# Altium schematic sheet TestBoard.SchDoc
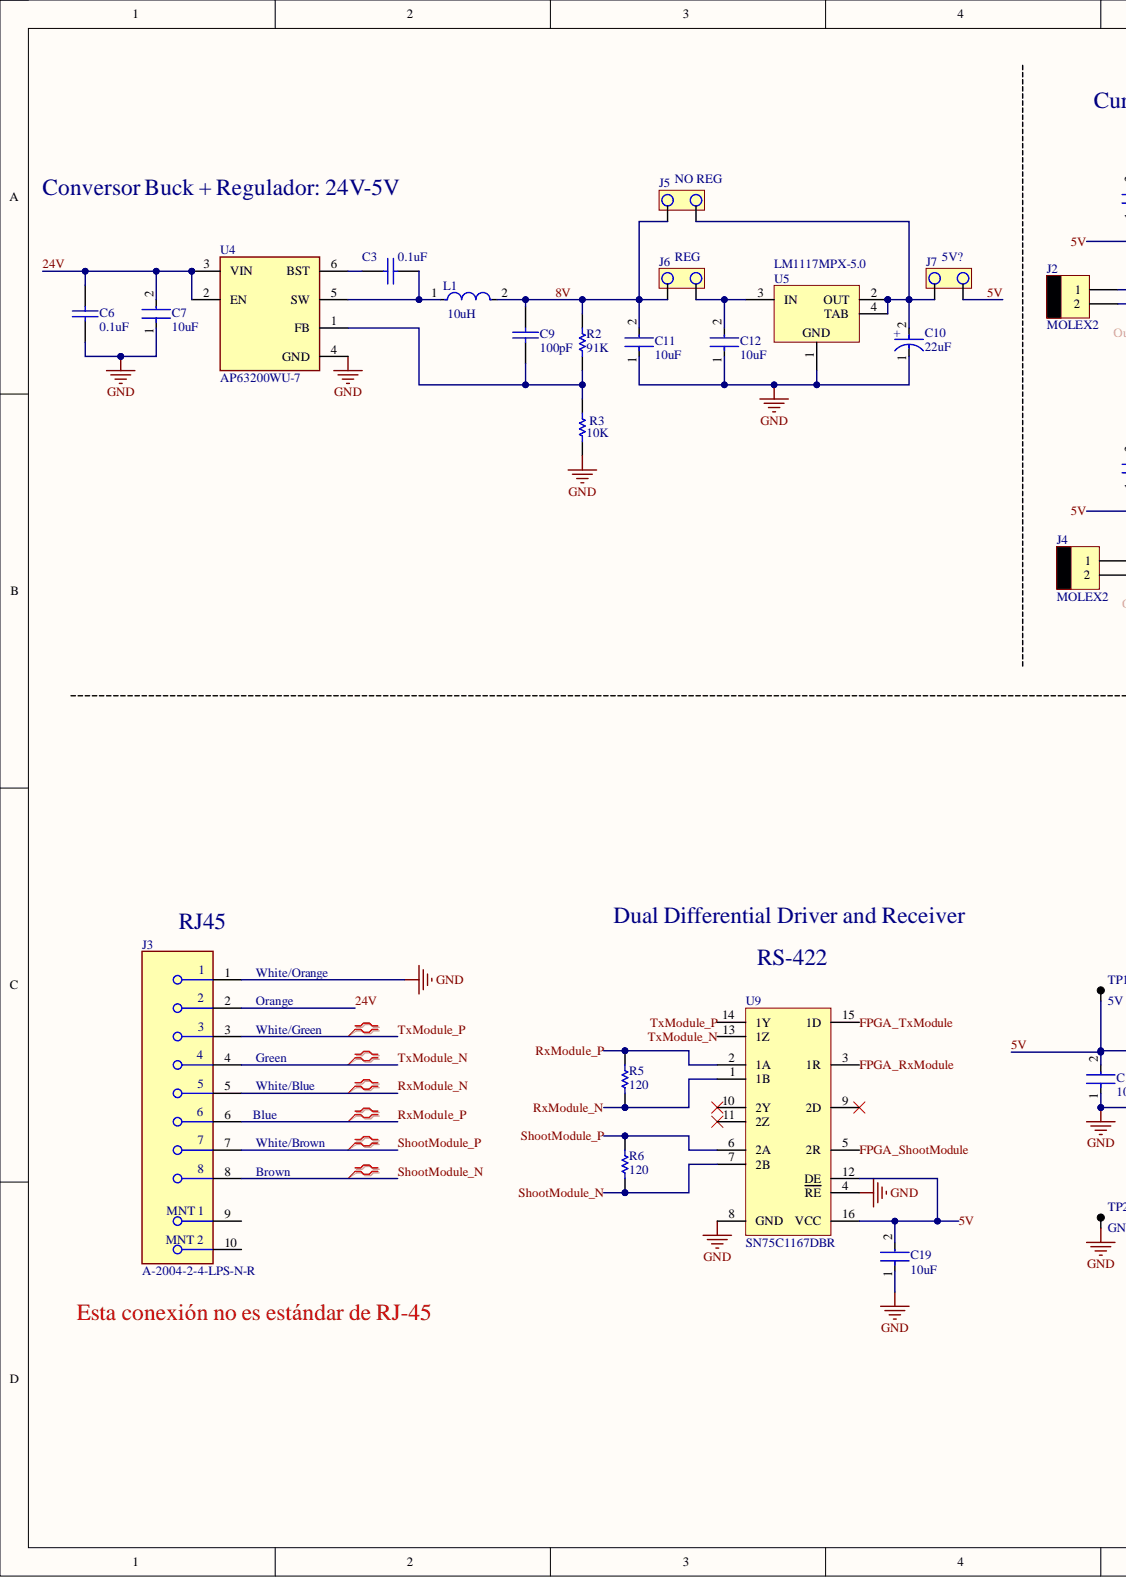
[diagram p0: TestBoard.SchDoc, left]
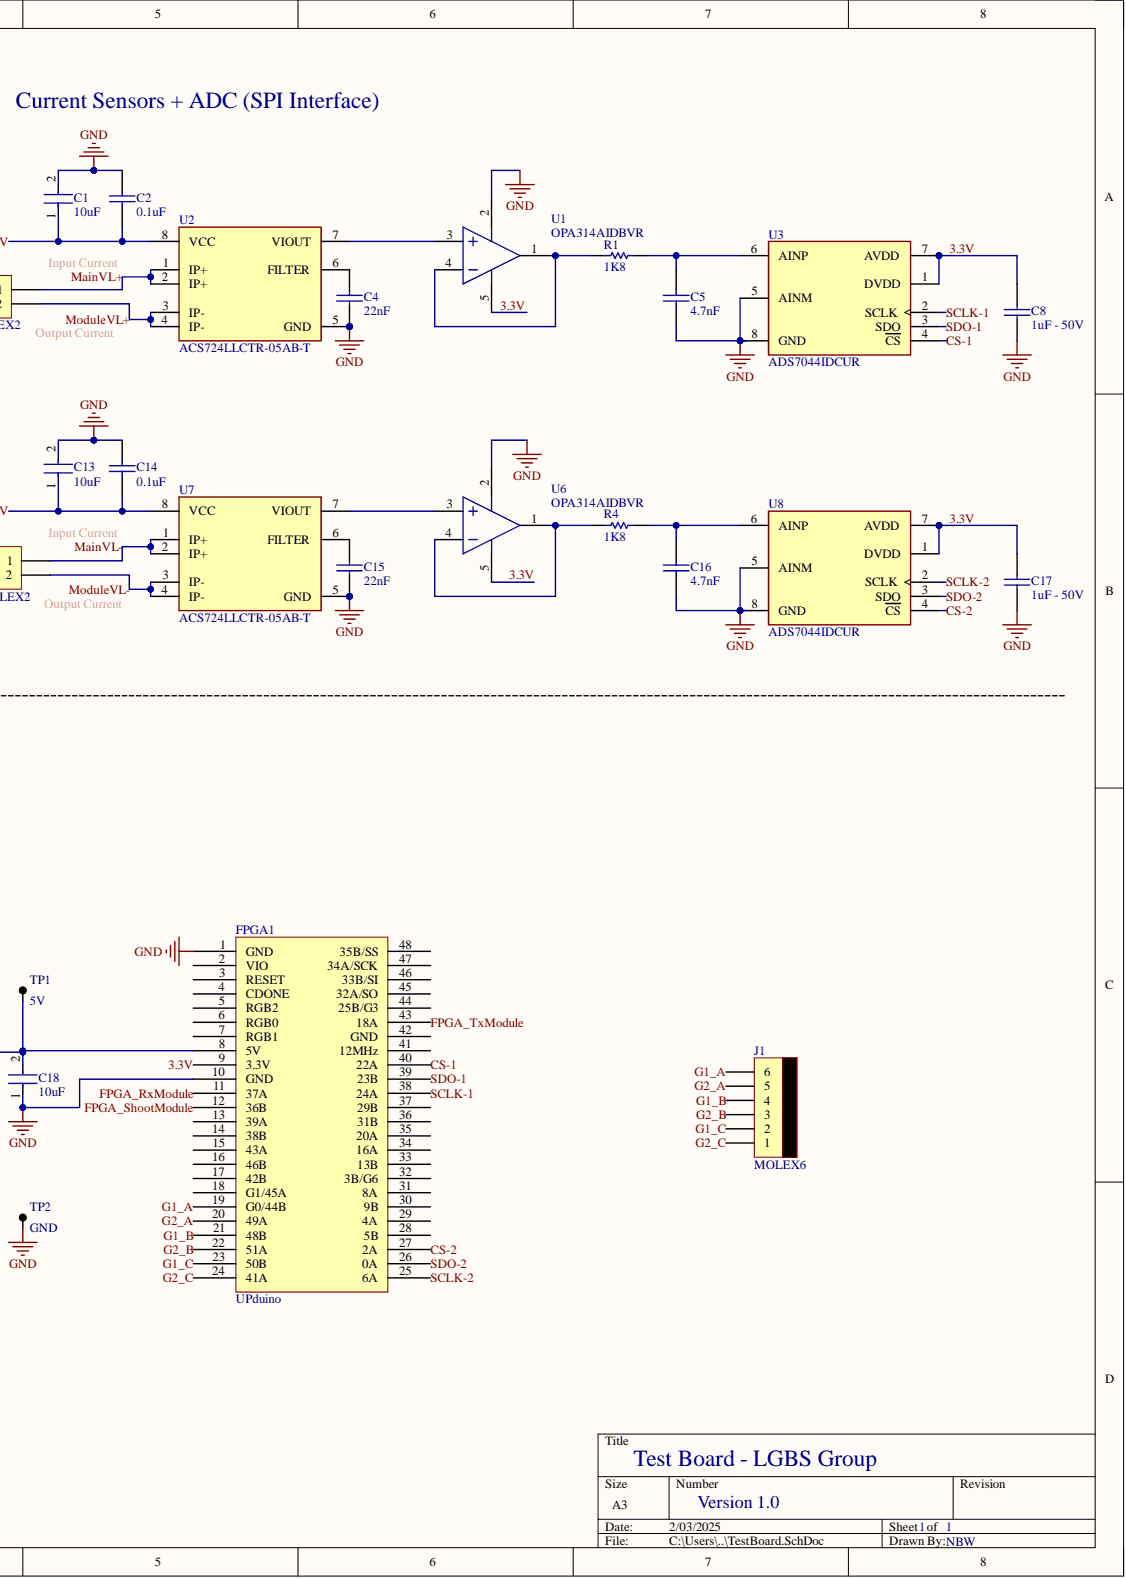
[diagram p1: TestBoard.SchDoc, right]

## Parts
C1  10uF  [FP-CL31B106KBHNNNE-IPC_A]
C2  0.1uF  [0805]
C3  0.1uF  [0805]
C4  22nF  [0805]
C5  4.7nF  [0805]
C6  0.1uF  [0805]
C7  10uF  [FP-CL31B106KBHNNNE-IPC_A]
C8  1uF - 50V  [0805]
C9  100pF  [0805]
C10  22uF  [FP-293DC-MFG]
C11  10uF  [FP-CL31B106KBHNNNE-IPC_A]
C12  10uF  [FP-CL31B106KBHNNNE-IPC_A]
C13  10uF  [FP-CL31B106KBHNNNE-IPC_A]
C14  0.1uF  [0805]
C15  22nF  [0805]
C16  4.7nF  [0805]
C17  1uF - 50V  [0805]
C18  10uF  [FP-CL31B106KBHNNNE-IPC_A]
C19  10uF  [FP-CL31B106KBHNNNE-IPC_A]
FPGA1  UPduino  [FPGA]
J1  MOLEX6  [MOLEX6]
J2  MOLEX2  [MOLEX2]
J3  A-2004-2-4-LPS-N-R  [FP-A-2004-2-4-LPS-N-R-MFG]
J4  MOLEX2  [MOLEX2]
J5  NO REG  [JUMPER2]
J6  REG  [JUMPER2]
J7  5V?  [JUMPER2]
L1  10uH  [FP-NR6045-MFG]
R1  1K8  [0805]
R2  91K  [0805]
R3  10K  [0805]
R4  1K8  [0805]
R5  120  [0805]
R6  120  [0805]
TP1  5V  [TPTH]
TP2  GND  [TPTH]
U1  OPA314AIDBVR  [DBV0005A_M]
U2  ACS724LLCTR-05AB-T  [FP-LCN-8-IPC_B]
U3  ADS7044IDCUR  [FP-DCU0008A-MFG]
U4  AP63200WU-7  [FP-TSOT26-MFG]
U5  LM1117MPX-5.0  [DCY0004A_L]
U6  OPA314AIDBVR  [DBV0005A_M]
U7  ACS724LLCTR-05AB-T  [FP-LCN-8-IPC_B]
U8  ADS7044IDCUR  [FP-DCU0008A-MFG]
U9  SN75C1167DBR  [MC34050]

## Nets
GND: C1.2 C2.1 C4.2 C5.2 C6.2 C7.1 C8.1 C10.1 C11.1 C12.1 C13.2 C14.1 C15.2 C16.2 C17.1 C18.1 C19.1 FPGA1.1(GND) FPGA1.10(GND) J3.1 R3.2 TP2.1 U1.2 U2.5(GND) U3.5(AINM) U3.8(GND) U4.4(GND) U5.1 U6.2 U7.5(GND) U8.5(AINM) U8.8(GND) U9.4(RE) U9.8(GND)
3.3V: C8.2 C17.2 FPGA1.9(3.3V) U1.5 U3.1(DVDD) U3.7(AVDD) U6.5 U8.1(DVDD) U8.7(AVDD)
5V: C1.1 C2.2 C13.1 C14.2 C18.2 C19.2 FPGA1.8(5V) J7.1 TP1.1 U2.8(VCC) U7.8(VCC) U9.12(DE) U9.16(VCC)
8V: C9.1 C11.2 J5.2 J6.2 L1.2 R2.1
24V: C6.1 C7.2 J3.2 U4.2(EN) U4.3(VIN)
CS-1: FPGA1.40(22A) U3.4(CS)
CS-2: FPGA1.27(2A) U8.4(CS)
FPGA_RxModule: FPGA1.11(37A) U9.3(1R)
FPGA_ShootModule: FPGA1.12(36B) U9.5(2R)
FPGA_TxModule: FPGA1.43(18A) U9.15(1D)
G1_A: FPGA1.19(G0/44B) J1.6
G1_B: FPGA1.21(48B) J1.4
G1_C: FPGA1.23(50B) J1.2
G2_A: FPGA1.20(49A) J1.5
G2_B: FPGA1.22(51A) J1.3
G2_C: FPGA1.24(41A) J1.1
MainVL+: J2.1 U2.1(IP+) U2.2(IP+)
MainVL-: J4.1 U7.1(IP+) U7.2(IP+)
ModuleVL+: J2.2 U2.3(IP-) U2.4(IP-)
ModuleVL-: J4.2 U7.3(IP-) U7.4(IP-)
RxModule_N: J3.5 R5.1 U9.1(1B)
RxModule_P: J3.6 R5.2 U9.2(1A)
SCLK-1: FPGA1.38(24A) U3.2(SCLK)
SCLK-2: FPGA1.25(6A) U8.2(SCLK)
SDO-1: FPGA1.39(23B) U3.3(SDO)
SDO-2: FPGA1.26(0A) U8.3(SDO)
ShootModule_N: J3.8 R6.1 U9.7(2B)
ShootModule_P: J3.7 R6.2 U9.6(2A)
TxModule_N: J3.4 U9.13(1Z)
TxModule_P: J3.3 U9.14(1Y)
NetC3_1: C3.1 U4.6(BST)
NetC3_2: C3.2 L1.1 U4.5(SW)
NetC4_1: C4.1 U2.6(FILTER)
NetC5_1: C5.1 R1.2 U3.6(AINP)
NetC9_2: C9.2 R2.2 R3.1 U4.1(FB)
NetC10_2: C10.2 J5.1 J7.2 U5.2(OUT) U5.4(TAB)
NetC12_2: C12.2 J6.1 U5.3(IN)
NetC15_1: C15.1 U7.6(FILTER)
NetC16_1: C16.1 R4.2 U8.6(AINP)
NetR1_1: R1.1 U1.1 U1.4
NetR4_1: R4.1 U6.1 U6.4
NetU1_3: U1.3 U2.7(VIOUT)
NetU6_3: U6.3 U7.7(VIOUT)

Not connected: FPGA1 2(VIO) 3(RESET) 4(CDONE) 5(RGB2) 6(RGB0) 7(RGB1) 13(39A) 14(38B) 15(43A) 16(46B) 17(42B) 18(G1/45A) 28(5B) 29(4A) 30(9B) 31(8A) 32(3B/G6) 33(13B) 34(16A) 35(20A) 36(31B) 37(29B) 41(12MHz) 42(GND) 44(25B/G3) 45(32A/SO) 46(33B/SI) 47(34A/SCK) 48(35B/SS); J3 9 10; U9 9(2D) 10(2Y) 11(2Z)

## Library data of the parts
C1 C7 C11-C13 C18 C19 = CMP-2000-07530-2: CAP CER 10UF 50V X7R 1206 -CL31B106KBHNNNE; Manufacturer: Samsung; Material: Ceramic; Temperature Coefficient: 15%; Tolerance: 10%; Automotive: No; Capacitance: 10uF; Category: Cap; Component Kind: Standard; ComponentLink2Description: Datasheet; ComponentLink2URL: http://www.samsungsem.com/global/front/downloadcms.do?path=%2F%2Fglobal%2F%2Fsupport%2F%2Fproduct-search%2F%2Fmlcc%2F%2F__icsFiles%2F%2Fafieldfile%2F%2F2019%2F%2F06%2F%2F18&fileName=CL31B106KBHNNNE-19.pdf; Device Class L1: Passive Components; Device Class L2: Capacitors; Device Class L3: Ceramic Capacitors; Digikey Description: CAP CER 10UF 50V X7R 1206; Height: 1.8mm; Lead Free: Yes; Library Name: Altium Content Vault; Manufacturer Part Number: CL31B106KBHNNNE; Octopart: https://octopart.com/cl31b106kbhnnne-samsung-30507377; Package: CL31B106KBHNNNE; RoHS: TRUE; Temperature: -55°C to +125°C; Temperature Characteristic: X7R; Temperature Range High: +125°C; Temperature Range Low: -55°C; Value: 10uF; Voltage Rating: 50V
C2-C6 C8 C9 C14-C17 = CAP: Component Kind: Standard; Library Name: LIBEBAL.IntLib
C10 = CMP-02414-000014-1: CAP TANT 22UF 10% 16V 2312 - 293D226X9016C2TE3; Dielectric Material: Tantalum; Dissipation Factor: 6%; ESR (Equivalent Series Resistance): 1.4R; Leakage Current: 3.5uA; Polarity: Polar; Q Factor: 6; Ripple Current: 210mA; Capacitance: 22uF; Case Code (Imperial): 2312; Case Code (Metric): 6032; Case/Package: Molded; Component Kind: Standard; Depth: 3.2mm; Features: General Purpose; Height: 2.5mm; Height - Seated (Max): 0.11inch; Lead Free: Lead Free; Length: 6mm; Library Name: Altium Content Vault; Max Operating Temperature: 125°C; Min Operating Temperature: -55°C; Mount: Surface Mount; Package Quantity: 1; Packaging: Tape and Reel; Radiation Hardening: No; RoHS Compliant: Yes; Series: TANTAMOUNT® 293D; Termination: SMD/SMT; Tolerance: 10%; Voltage: 16V; Voltage Rating: 16V; Voltage Rating (DC): 16V; Width: 0.126inch
FPGA1 = FPGA: upduino v3.0; Component Kind: Standard; Library Name: MCSI.SchLib
J3 = CMP-39752-000001-1: CONN MOD JACK 8P8C R/A SHIELDED; Direction: Down; Gender: Female; Max Current Rating: 1.5A; Max Voltage Rating (AC): 120V; Number of Contacts: 8; Number of Ports: 1; Pitch: 2.54mm; Component Kind: Standard; Connector Type: Jack; Contact Material: Bronze; Contact Plating: Gold; Housing Material: PBT; Lead Free: Lead Free; Library Name: Altium Content Vault; Max Operating Temperature: 85°C; Min Operating Temperature: -40°C; Mount: Through Hole; Number of Rows: 1; Orientation: Right Angle; Package Quantity: 100; Packaging: Tray; RoHS Compliant: Yes; Series: -; Shielding: Shielded; Termination: Solder
J5-J7 = JUMPER: Component Kind: Standard; Library Name: LIBEBAL.IntLib
L1 = CMP-14484-001321-1: Shielded Wirewound Inductor 10uH 20% 2.8A 59.8mΩ SMD - NRS6045T100MMGK; Core Material: Ferrite; Current Rating: 2.4A; DC Resistance (DCR): 59.8mR; Max DC Current: 3.1A; Self Resonant Frequency: 12MHz; Test Frequency: 100kHz; Case/Package: Nonstandard; Component Kind: Standard; Depth: 6.2mm; Halogen Free: Halogen Free; Height: 4.5mm; Height - Seated (Max): 0.177inch; Inductance: 10uH; Inductance Tolerance: 20%; Lead Free: Lead Free; Lead Pitch: 4.7mm; Length: 6mm; Library Name: Altium Content Vault; Max Operating Temperature: 125°C; Min Operating Temperature: -25°C; Mount: Surface Mount; Number of Pins: 2; Package Quantity: 1500; Packaging: Tape and Reel; Radiation Hardening: No; Resistor Type: Wirewound; RMS Current (Irms): 2.4A; RoHS Compliant: Yes; Saturation Current: 3.1A; Series: NRS; Shielding: Shielded; Termination: SMD/SMT; Tolerance: 20%; Width: 0.236inch
R1-R6 = RES: Component Kind: Standard; Library Name: LIBEBAL.IntLib
TP1 TP2 = TP: Component Kind: Standard; Library Name: LIBEBAL.IntLib
U1 U6 = CMP-0272-00944-3: 3 MHz, Low-Power, Low-Noise, RR I/O, CMOS Operational Amplifier, 1.8 to 5.5 V, -40 to 125 degC, 5-pin SOT23 (DBV5), Green (RoHS & no Sb/Br); Manufacturer: Texas Instruments; CMRR(Min)(dB): 75; GBW(Typ)(MHz): 3; IIB(Max)(pA): 10; Iq per channel(Max)(mA): 0.21; Number of Channels: 1; Packing: LARGE T&R; Rating: Catalog; Slew Rate(Typ)(V/us): 1.5; Sub Family: Low Input Bias Current Amp (<=10pA), Low Power Amp (<=500uA), Low Supply Voltage Amplifier (<=2.7V), Precision Amplifier; Total Supply Voltage(Max)(+5V is 5, +/-5V is 10): 5.5; Total Supply Voltage(Min)(+5V is 5, +/-5V is 10): 1.8; Vio (25C)(Max)(mV): 2.5; Code_JEDEC: MO-178; Component Kind: Standard; ComponentLink1Description: Manufacturer URL; ComponentLink1URL: http://www.ti.com/; ComponentLink2Description: Datasheet; ComponentLink2URL: http://www.ti.com/lit/ds/symlink/opa314.pdf; ComponentLink3Description: Package Specification; ComponentLink3URL: http://www.ti.com/litv/pdf/mpds018i; DatasheetVersion: SBOS563E; Eco-Plan: Green (RoHS & no Sb/Br); Library Name: Altium Content Vault; Mounting Technology: Surface Mount; Offset Drift(Typ)(uV/C): 1; PackageDescription: 5-Pin Plastic Small Outline, Body 1.6 x 2.9 mm, Pitch 0.95 mm; PackageReference: DBV0005A; PackageVersion: 4073253-4/K, 03/2006; PartNumber: OPA314AIDBVR
U2 U7 = CMP-82923-000070-2: SENSOR CURRENT HALL; Accuracy: 0.6%; Current Sensing: 5A; Frequency: 120kHz; Linearity: 1.5%; Max Supply Current: 14mA; Number of Channels: 1; Polarity: Bidirectional; Response Time: 4us; Sensitivity: 400mV/A; Case/Package: SOIC; Component Kind: Standard; Library Name: Altium Content Vault; Max Operating Temperature: 150°C; Min Operating Temperature: -40°C; Mount: Surface Mount; Packaging: Tape and Reel; RoHS Compliant: Yes
U3 U8 = CMP-04860-000057-1: IC ADC 12BIT SAR 8VSSOP; Integral Nonlinearity (INL): 2LSB; Interface: SPI; Max Dual Supply Voltage: 3.6V; Max Power Dissipation: 900uW; Min Dual Supply Voltage: 1.8V; Number of A/D Converters: 1; Number of Bits: 12; Operating Supply Voltage: 3V; Polarity: Unipolar; Resolution: 12b; Sampling Rate: 1000000S/s; Signal to Noise Ratio (SNR): 71dB; Supply Type: Analog; Component Kind: Standard; Contact Plating: Gold; Lead Free: Lead Free; Library Name: Altium Content Vault; Max Operating Temperature: 125°C; Max Supply Voltage: 3.6V; Min Operating Temperature: -40°C; Mount: Surface Mount; Number of Analog Inputs: 1; Number of Inputs: 1; Number of Pins: 8; Packaging: Tape and Reel; RoHS Compliant: Yes
U4 = CMP-12757-000010-1: IC REG BUCK ADJUSTABLE 2A TSOT26; Manufacturer: Diodes Inc.; Max Junction Temp: +160°C; Max Supply Voltage: 32V; Min Supply Voltage: 3.8V; Nominal Supply Current: 22uA; Number Of Outputs: 1; Output Type: AdjustableProgrammable; Standoff Height: 0.01mm; Switching Frequency: 500kHz; Ambient Temperature Range High: +85°C; Ambient Temperature Range Low: -40°C; Automotive: No; Category: IC; Component Kind: Standard; ComponentLink2Description: Datasheet; ComponentLink2URL: https://www.diodes.com/assets/Datasheets/AP63200-AP63201-AP63203-AP63205.pdf; Device Class L1: Integrated Circuits (ICs); Device Class L2: Power Management ICs; Device Class L3: Voltage Regulators - Switching; Digikey Description: IC REG BUCK ADJUSTABLE 2A TSOT26; Footprint Url: https://www.diodes.com/assets/Package-Files/TSOT26.pdf; Height: 1mm; Ipc Land Pattern Name: SOT95P280X100-6; Lead Free: Yes; Library Name: Altium Content Vault; Manufacturer Part Number: AP63200WU-7; Mouser Description: AP63200 Series 1.2 V 2 A Adjustable Synchronous Buck Converter - TSOT-26; Octopart: https://octopart.com/ap63200wu-7-diodes+inc.-98099548; Output Current: 2A; Package: TSOT26; RoHS: TRUE; Switching Topology: Buck; Temperature: -40°C to +85°C; Temperature Range High: +85°C; Temperature Range Low: -40°C
U5 = CMP-0062-01637-4: 800mA Low-Dropout Linear Regulator, 4-pin SOT-223; Manufacturer: Texas Instruments; CompType: LDO IC; High-Reliability: Y; PackageTopMarking: XYTT*N06A; PowerWise: N; Code_IPC: SOT223-4; Code_JEDEC: TO-261-AA; Component Kind: Standard; ComponentLink1Description: Datasheet URL; ComponentLink1URL: http://www.ti.com/general/docs/lit/getliterature.tsp?genericPartNumber=LM1117-N&fileType=pdf; Library Name: Altium Content Vault; PackageDescription: Molded SOT-223, 6.50 x 3.56 x 1.6mm Body, 4 Lead, 2.3mm Pitch; PackageReference: DCY0004A; PartNumber: LM1117MPX-5.0; PinCount: 4; RoHS: N
U9 = CMP-0310-00497-2: Dual Differential Driver and Receiver, 2 TX / 2 RX, 5V, 0 to 70 degC, 16-Pin SSOP (DB), Green (RoHS & no Sb/Br); Manufacturer: Texas Instruments; Drivers Per Package: 2; ICC(Max)(mA): 9; Rating: Catalog; SubFamily: RS-422; Supply Voltage(s)(V): 5; # of TX/RX: 2 TX / 2 RX; Code_JEDEC: MO-150; Component Kind: Standard; ComponentLink1Description: Datasheet; ComponentLink1URL: http://www.ti.com/general/docs/lit/getliterature.tsp?genericPartNumber=SN75C1167&fileType=pdf; ComponentLink2Description: Package Specification; ComponentLink2URL: http://www.ti.com/lit/ml/msso002e/msso002e.pdf; DatasheetVersion: SLLS159F; Eco-Plan: Green (RoHS & no Sb/Br); ESD(kV): 2; Library Name: Altium Content Vault; Mounting Technology: Surface Mount; PackageDescription: 16-Pin Plastic Small Outline Package, 6.2 x 5.3 mm Body, 0.65 mm Pitch; PackageReference: DB0016A; PackageVersion: revE, Dec-2001; PartNumber: SN75C1167DBR; Receivers Per Package: 2
J1 = MOLEX6: Component Kind: Standard; Library Name: LIBEBAL.IntLib
J2 J4 = MOLEX2: Component Kind: Standard; Library Name: LIBEBAL.IntLib
